# Altium schematic sheet gate_driving_slave_3.SchDoc(gate_driving_slave_3)
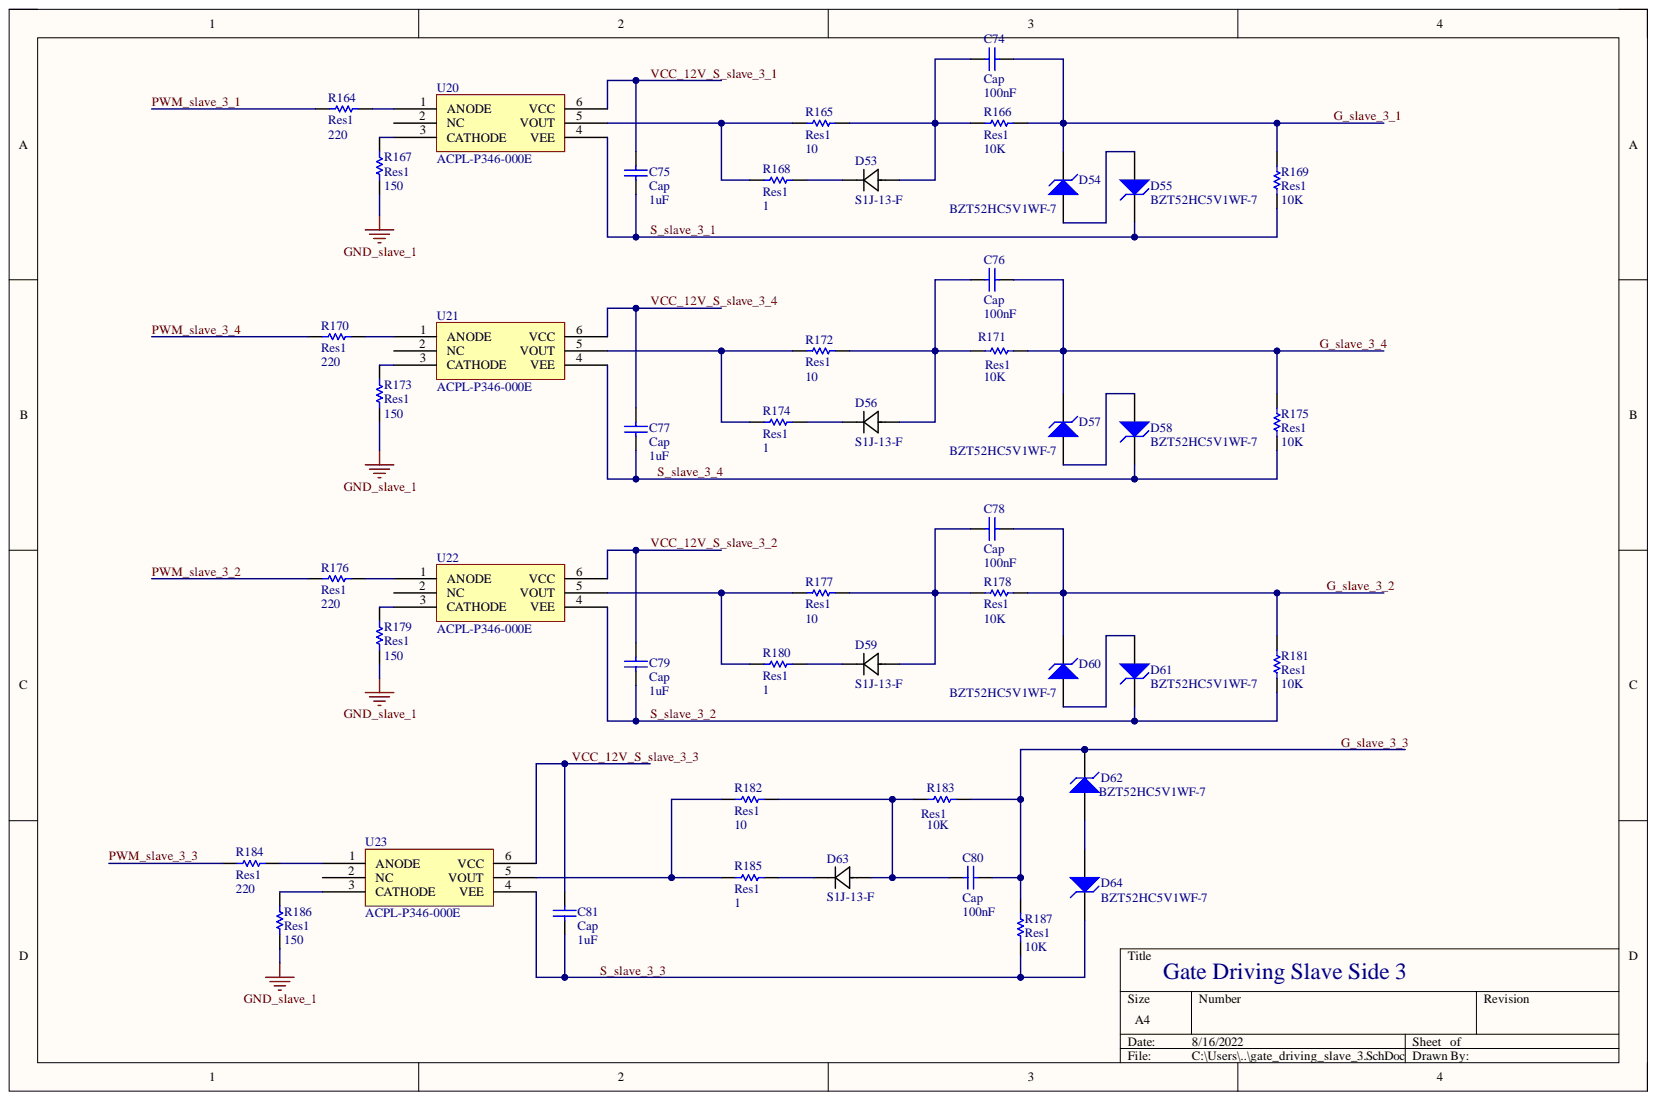
[diagram p0: gate_driving_slave_3.SchDoc]

## Parts
C74  Cap  value 100nF  [C0805]
C75  Cap  value 1uF  [C0805]
C76  Cap  value 100nF  [C0805]
C77  Cap  value 1uF  [C0805]
C78  Cap  value 100nF  [C0805]
C79  Cap  value 1uF  [C0805]
C80  Cap  value 100nF  [C0805]
C81  Cap  value 1uF  [C0805]
D53  S1J-13-F  [SMA_DIO]
D54  BZT52HC5V1WF-7  [DIODE-0.7]
D55  BZT52HC5V1WF-7  [DIODE-0.7]
D56  S1J-13-F  [SMA_DIO]
D57  BZT52HC5V1WF-7  [DIODE-0.7]
D58  BZT52HC5V1WF-7  [DIODE-0.7]
D59  S1J-13-F  [SMA_DIO]
D60  BZT52HC5V1WF-7  [DIODE-0.7]
D61  BZT52HC5V1WF-7  [DIODE-0.7]
D62  BZT52HC5V1WF-7  [DIODE-0.7]
D63  S1J-13-F  [SMA_DIO]
D64  BZT52HC5V1WF-7  [DIODE-0.7]
R164  Res1  value 220  [RES0805W]
R165  Res1  value 10  [RES0805W]
R166  Res1  value 10K  [RES0805W]
R167  Res1  value 150  [RES0805W]
R168  Res1  value 1  [RES0805W]
R169  Res1  value 10K  [RES0805W]
R170  Res1  value 220  [RES0805W]
R171  Res1  value 10K  [RES0805W]
R172  Res1  value 10  [RES0805W]
R173  Res1  value 150  [RES0805W]
R174  Res1  value 1  [RES0805W]
R175  Res1  value 10K  [RES0805W]
R176  Res1  value 220  [RES0805W]
R177  Res1  value 10  [RES0805W]
R178  Res1  value 10K  [RES0805W]
R179  Res1  value 150  [RES0805W]
R180  Res1  value 1  [RES0805W]
R181  Res1  value 10K  [RES0805W]
R182  Res1  value 10  [RES0805W]
R183  Res1  value 10K  [RES0805W]
R184  Res1  value 220  [RES0805W]
R185  Res1  value 1  [RES0805W]
R186  Res1  value 150  [RES0805W]
R187  Res1  value 10K  [RES0805W]
U20  ACPL-P346-000E  [ACPL-P346-000E]
U21  ACPL-P346-000E  [ACPL-P346-000E]
U22  ACPL-P346-000E  [ACPL-P346-000E]
U23  ACPL-P346-000E  [ACPL-P346-000E]

## Nets
GND_slave_1: R167.1 R173.1 R179.1 R186.1
G_slave_3_1: C74.1 D54.2 R166.2 R169.2
G_slave_3_2: C78.1 D60.2 R178.2 R181.2
G_slave_3_3: C80.1 D62.2 R183.2 R187.2
G_slave_3_4: C76.1 D57.2 R171.2 R175.2
PWM_slave_3_1: R164.1
PWM_slave_3_2: R176.1
PWM_slave_3_3: R184.1
PWM_slave_3_4: R170.1
S_slave_3_1: C75.1 D55.2 R169.1 U20.4(VEE)
S_slave_3_2: C79.1 D61.2 R181.1 U22.4(VEE)
S_slave_3_3: C81.1 D64.2 R187.1 U23.4(VEE)
S_slave_3_4: C77.1 D58.2 R175.1 U21.4(VEE)
VCC_12V_S_slave_3_1: C75.2 U20.6(VCC)
VCC_12V_S_slave_3_2: C79.2 U22.6(VCC)
VCC_12V_S_slave_3_3: C81.2 U23.6(VCC)
VCC_12V_S_slave_3_4: C77.2 U21.6(VCC)
NetC74_2: C74.2 D53.2 R165.2 R166.1
NetC76_2: C76.2 D56.2 R171.1 R172.2
NetC78_2: C78.2 D59.2 R177.2 R178.1
NetC80_2: C80.2 D63.2 R182.2 R183.1
NetD53_1: D53.1 R168.2
NetD54_1: D54.1 D55.1
NetD56_1: D56.1 R174.2
NetD57_1: D57.1 D58.1
NetD59_1: D59.1 R180.2
NetD60_1: D60.1 D61.1
NetD62_1: D62.1 D64.1
NetD63_1: D63.1 R185.2
NetR164_2: R164.2 U20.1(ANODE)
NetR165_1: R165.1 R168.1 U20.5(VOUT)
NetR167_2: R167.2 U20.3(CATHODE)
NetR170_2: R170.2 U21.1(ANODE)
NetR172_1: R172.1 R174.1 U21.5(VOUT)
NetR173_2: R173.2 U21.3(CATHODE)
NetR176_2: R176.2 U22.1(ANODE)
NetR177_1: R177.1 R180.1 U22.5(VOUT)
NetR179_2: R179.2 U22.3(CATHODE)
NetR182_1: R182.1 R185.1 U23.5(VOUT)
NetR184_2: R184.2 U23.1(ANODE)
NetR186_2: R186.2 U23.3(CATHODE)

Not connected: U20 2(NC); U21 2(NC); U22 2(NC); U23 2(NC)

## Library data of the parts
C74 C76 C78 = Cap: Capacitor; Value: 100nF; Component Kind: Standard; LatestRevisionDate: 17-Jul-2002; LatestRevisionNote: Re-released for DXP Platform.; Library Name: Miscellaneous Devices.IntLib; PackageDescription: Radial Cap, Thru-Hole; 2 Leads; 0.3 in Pin Spacing; PackageReference: RAD-0.3; Published: 8-Jun-2000; Signal Integrity: Cap; Simulation: CAP; Publisher: Altium Limited
C75 C77 C79-C81 = Cap: Capacitor; Component Kind: Standard; LatestRevisionDate: 17-Jul-2002; LatestRevisionNote: Re-released for DXP Platform.; Library Name: Miscellaneous Devices.IntLib; PackageDescription: Radial Cap, Thru-Hole; 2 Leads; 0.3 in Pin Spacing; PackageReference: RAD-0.3; Published: 8-Jun-2000; Signal Integrity: Cap; Simulation: CAP; Publisher: Altium Limited
D53 D56 D59 D63 = S1J-13-F: No Description Available; Mfr_Name: Diodes Inc; Type: DEV; Component Kind: Standard; Digi-Key_Part_Number_1: S1J-FDICT-ND; Digi-Key_Part_Number_2: S1J-FDIDKR-ND; Digi-Key_Part_Number_3: S1J-FDITR-ND; Library Name: S1J-13-F.SchLib; Manufacturer_Part_Number: S1J-13-F; RefDes: RefDes; Copyright: Copyright (C) 2022 Ultra Librarian. All rights reserved.
D54 D55 D57 D58 D60-D62 D64 = D Zener: Zener Diode; Component Kind: Standard; LatestRevisionDate: 17-Jul-2002; LatestRevisionNote: Re-released for DXP Platform.; Library Name: Miscellaneous Devices.IntLib; PackageDescription: Diode, Thru-Hole; 2 Leads; 0.7 in Pin Spacing; PackageReference: DIODE-0.7; Published: 8-Jun-2000; Signal Integrity: D Zener; Simulation: ZENER; Publisher: Altium Limited
R164-R187 = Res1: Resistor; Component Kind: Standard; LatestRevisionDate: 17-Jul-2002; LatestRevisionNote: Re-released for DXP Platform.; Library Name: Miscellaneous Devices.IntLib; PackageDescription: Axial Device, Thru-Hole; 2 Leads; 0.3 in Pin Spacing; PackageReference: AXIAL-0.3; Published: 8-Jun-2000; Signal Integrity: Res; Simulation: RESISTOR; Publisher: Altium Limited
U20-U23 = ACPL-P346-000E: Component Kind: Standard; Library Name: ACPL-P346-000E.SchLib
